# Altium schematic sheet PC.SchDoc(PC)
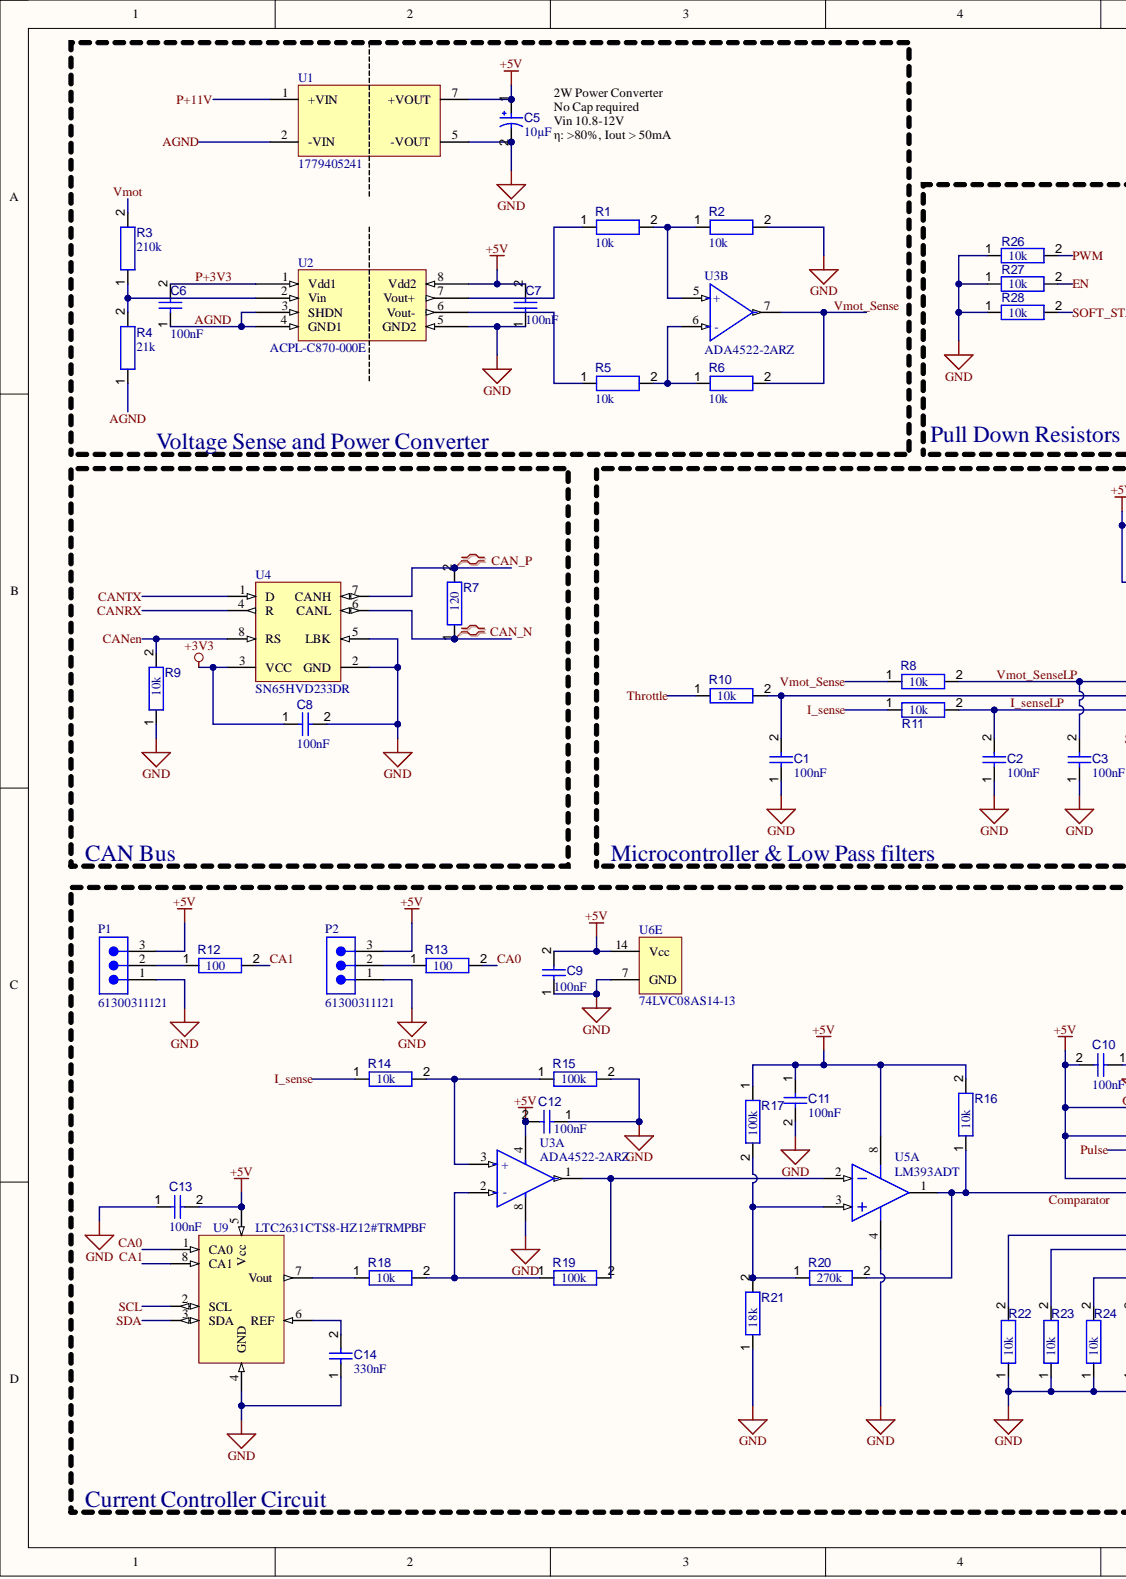
[diagram p0: PC.SchDoc, left]
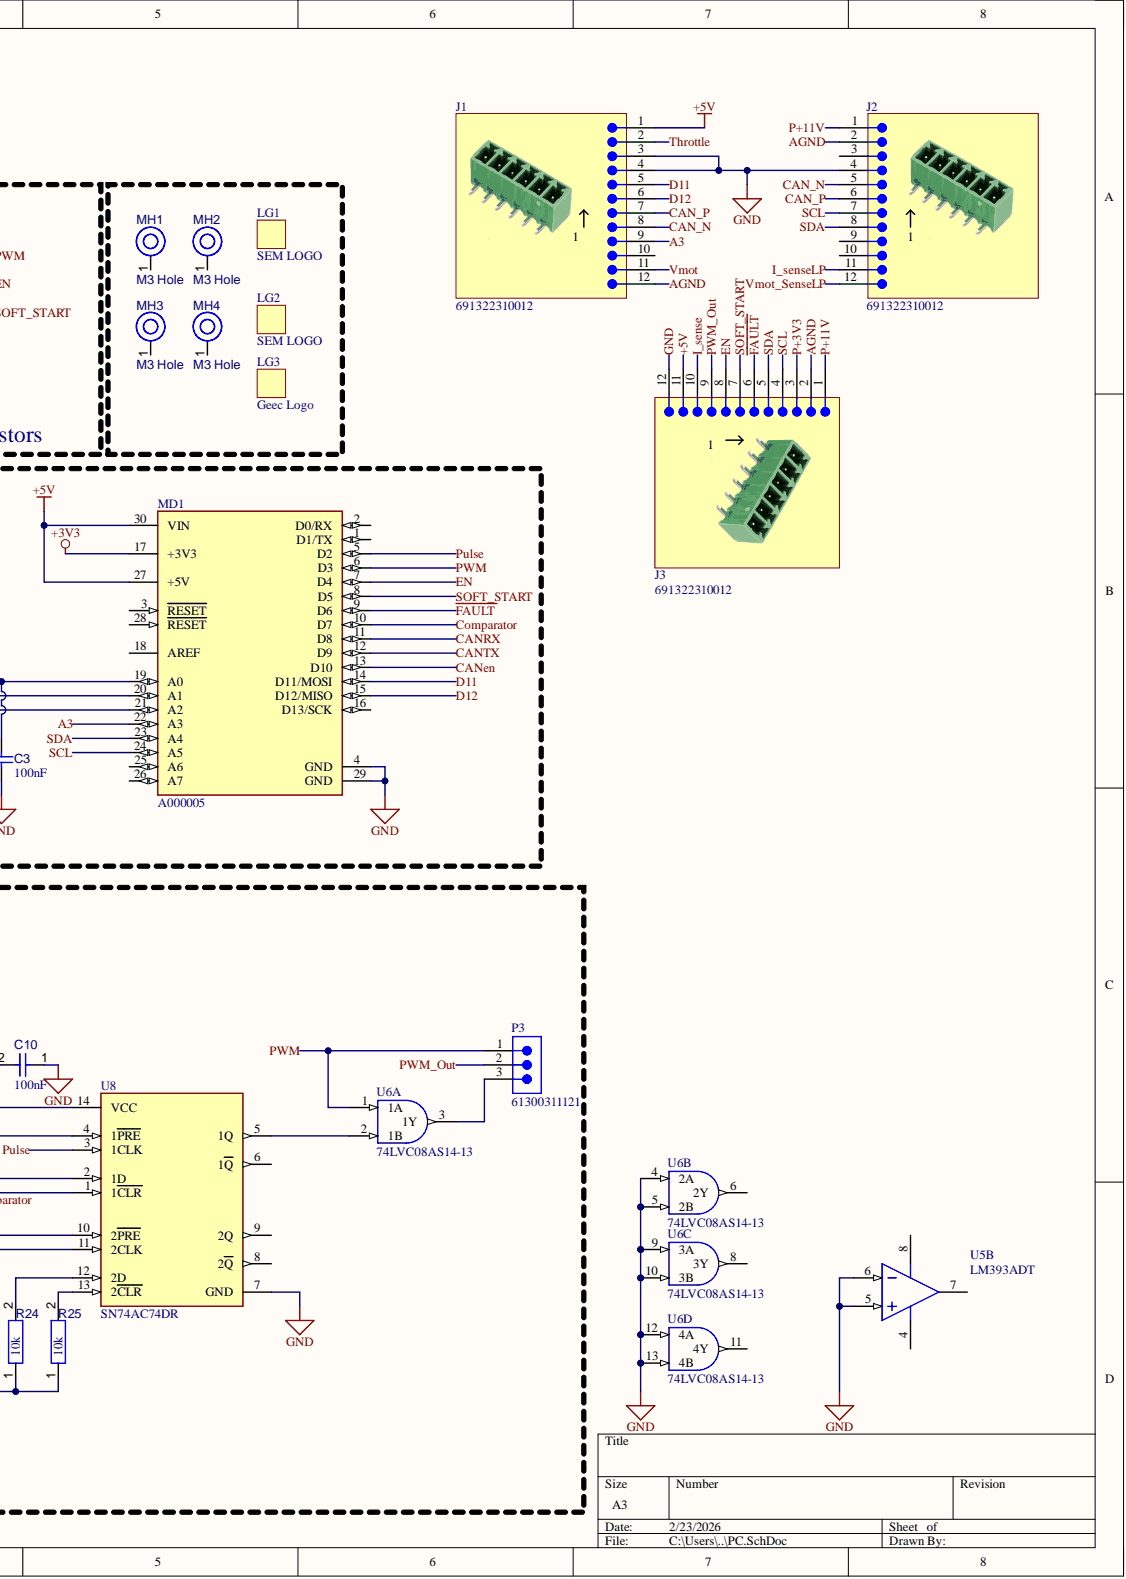
[diagram p1: PC.SchDoc, right]

## Parts
C1  value 100nF  [Cap-THT-Ceramic(7.2X2.5)]  (hidden comment: 100n-THT)
C2  value 100nF  [Cap-THT-Ceramic(7.2X2.5)]  (hidden comment: 100n-THT)
C3  value 100nF  [Cap-THT-Ceramic(7.2X2.5)]  (hidden comment: 100n-THT)
C5  value 10µF  [CAPPR55-200-1100X500X1200]  (hidden comment: ECA1HM100)
C6  value 100nF  [Cap-THT-Ceramic(7.2X2.5)]  (hidden comment: 100n-THT)
C7  value 100nF  [Cap-THT-Ceramic(7.2X2.5)]  (hidden comment: 100n-THT)
C8  value 100nF  [Cap-THT-Ceramic(7.2X2.5)]  (hidden comment: 100n-THT)
C9  value 100nF  [Cap-THT-Ceramic(7.2X2.5)]  (hidden comment: 100n-THT)
C10  value 100nF  [Cap-THT-Ceramic(7.2X2.5)]  (hidden comment: 100n-THT)
C11  value 100nF  [Cap-THT-Ceramic(7.2X2.5)]  (hidden comment: 100n-THT)
C12  value 100nF  [Cap-THT-Ceramic(7.2X2.5)]  (hidden comment: 100n-THT)
C13  value 100nF  [Cap-THT-Ceramic(7.2X2.5)]  (hidden comment: 100n-THT)
C14  value 330nF  [Cap-THT-Ceramic(7.2X2.5)]  (hidden comment: 330nF-THT-Film)
J1  691322310012  [691322310012]
J2  691322310012  [691322310012]
J3  691322310012  [691322310012]
LG1  SEM LOGO  [SEM LOGO-Footprint-1]
LG2  SEM LOGO  [SEM LOGO-Footprint-1]
LG3  Geec Logo  [Geec Logo-Footprint-1]
MD1  A000005  [FP-A000005-MFG]
MH1  M3 Hole  [M3 Hole-Footprint-1]
MH2  M3 Hole  [M3 Hole-Footprint-1]
MH3  M3 Hole  [M3 Hole-Footprint-1]
MH4  M3 Hole  [M3 Hole-Footprint-1]
P1  61300311121  [61300311121]
P2  61300311121  [61300311121]
P3  61300311121  [61300311121]
R1  value 10k  [RESA60-630X240]  (hidden comment: 10k-ThroughHole-250mW)
R2  value 10k  [RESA60-630X240]  (hidden comment: 10k-ThroughHole-250mW)
R3  value 210k  [RESA60-630X240]  (hidden comment: 210k-Throughhole-250mW)
R4  value 21k  [RESA60-630X240]  (hidden comment: 21k-Throughhole-250mW)
R5  value 10k  [RESA60-630X240]  (hidden comment: 10k-ThroughHole-250mW)
R6  value 10k  [RESA60-630X240]  (hidden comment: 10k-ThroughHole-250mW)
R7  value 120  [RESA60-630X240]  (hidden comment: 120Ohm-Throughhole-250mW)
R8  value 10k  [RESA60-630X240]  (hidden comment: 10k-ThroughHole-250mW)
R9  value 10k  [RESA60-630X240]  (hidden comment: 10k-ThroughHole-250mW)
R10  value 10k  [RESA60-630X240]  (hidden comment: 10k-ThroughHole-250mW)
R11  value 10k  [RESA60-630X240]  (hidden comment: 10k-ThroughHole-250mW)
R12  value 100  [RESA60-630X240]  (hidden comment: 100Ohm-ThroughHole-250mW)
R13  value 100  [RESA60-630X240]  (hidden comment: 100Ohm-ThroughHole-250mW)
R14  value 10k  [RESA60-630X240]  (hidden comment: 10k-ThroughHole-250mW)
R15  value 100k  [RESA60-630X240]  (hidden comment: 100k-ThroughHole-250mW)
R16  value 10k  [RESA60-630X240]  (hidden comment: 10k-ThroughHole-250mW)
R17  value 100k  [RESA60-630X240]  (hidden comment: 100k-ThroughHole-250mW)
R18  value 10k  [RESA60-630X240]  (hidden comment: 10k-ThroughHole-250mW)
R19  value 100k  [RESA60-630X240]  (hidden comment: 100k-ThroughHole-250mW)
R20  value 270k  [RESA60-630X240]  (hidden comment: 270k-ThroughHole-250mW)
R21  value 18k  [RESA60-630X240]  (hidden comment: 18kOhm-ThroughHole-250mW)
R22  value 10k  [RESA60-630X240]  (hidden comment: 10k-ThroughHole-250mW)
R23  value 10k  [RESA60-630X240]  (hidden comment: 10k-ThroughHole-250mW)
R24  value 10k  [RESA60-630X240]  (hidden comment: 10k-ThroughHole-250mW)
R25  value 10k  [RESA60-630X240]  (hidden comment: 10k-ThroughHole-250mW)
R26  value 10k  [RESA60-630X240]  (hidden comment: 10k-ThroughHole-250mW)
R27  value 10k  [RESA60-630X240]  (hidden comment: 10k-ThroughHole-250mW)
R28  value 10k  [RESA60-630X240]  (hidden comment: 10k-ThroughHole-250mW)
U1  1779405241  [SIP-7_1779405241]
U2  ACPL-C870-000E  [SOIC127P1150X173-8M]
U3  ADA4522-2ARZ  [FP-R-8-IPC_C]  (parts A,B)
U4  SN65HVD233DR  [D0008A_L]
U5  LM393ADT  [ONSC-SOIC-8-751-07_AJ_V]  (parts A,B)
U6  74LVC08AS14-13  [SOIC127P600X173-14N]  (parts A,B,C,D,E)
U8  SN74AC74DR  [FP-D0014A-MFG]
U9  LTC2631CTS8-HZ12#TRMPBF  [TSOP65P280X100-8N]

## Nets
+3V3: C8.1 MD1.17(+3V3) U4.3(VCC)
+5V: C5.1 C7.2 C9.2 C10.2 C11.1 C12.2 C13.2 J1.1 J3.11 MD1.27(+5V) MD1.30(VIN) P1.3 P2.3 R16.2 R17.1 U1.7(+VOUT) U2.8(Vdd2) U3.4 U5.8 U6.14(Vcc) U8.2(1D) U8.4(1PRE) U8.14(VCC) U9.5(Vcc)
~{FAULT}: J3.6 MD1.9(D6)
A3: J1.9 MD1.22(A3)
AGND: C6.1 J1.12 J2.2 J3.2 R4.1 U1.2(-VIN) U2.3(SHDN) U2.4(GND1)
CA0: R13.2 U9.1(CA0)
CA1: R12.2 U9.8(CA1)
CAN_N: J1.8 J2.5 R7.1 U4.6(CANL)
CAN_P: J1.7 J2.6 R7.2 U4.7(CANH)
CANen: MD1.13(D10) R9.2 U4.8(RS)
CANRX: MD1.11(D8) U4.4(R)
CANTX: MD1.12(D9) U4.1(D)
Comparator: MD1.10(D7) R16.1 R20.2 U5.1 U8.1(1CLR)
D11: J1.5 MD1.14(D11/MOSI)
D12: J1.6 MD1.15(D12/MISO)
EN: J3.8 MD1.7(D4) R27.2
GND: C1.1 C2.1 C3.1 C5.2 C7.1 C8.2 C9.1 C10.1 C11.2 C12.1 C13.1 C14.1 J1.3 J1.4 J2.4 J3.12 MD1.4(GND) MD1.29(GND) P1.1 P2.1 R2.2 R9.1 R15.2 R21.1 R22.1 R23.1 R24.1 R25.1 R26.1 R27.1 R28.1 U1.5(-VOUT) U2.5(GND2) U3.8 U4.2(GND) U4.5(LBK) U5.4 U5.5 U5.6 U6.4(2A) U6.5(2B) U6.7(GND) U6.9(3A) U6.10(3B) U6.12(4A) U6.13(4B) U8.7(GND) U9.4(GND)
I_sense: J3.10 R11.1 R14.1
I_senseLP: C2.2 J2.11 MD1.21(A2) R11.2
P+3V3: C6.2 J3.3 U2.1(Vdd1)
P+11V: J2.1 J3.1 U1.1(+VIN)
Pulse: MD1.5(D2) U8.3(1CLK)
PWM: MD1.6(D3) P3.1 R26.2 U6.1(1A)
PWM_Out: J3.9 P3.2
SCL: J2.7 J3.4 MD1.24(A5) U9.2(SCL)
SDA: J2.8 J3.5 MD1.23(A4) U9.3(SDA)
SOFT_START: J3.7 MD1.8(D5) R28.2
Throttle: J1.2 R10.1
Vmot: J1.11 R3.2
Vmot_Sense: R6.2 R8.1 U3.7
Vmot_SenseLP: C3.2 J2.12 MD1.19(A0) R8.2
NetC1_2: C1.2 MD1.20(A1) R10.2
NetC14_2: C14.2 U9.6(REF)
NetP1_2: P1.2 R12.1
NetP2_2: P2.2 R13.1
NetP3_3: P3.3 U6.3(1Y)
NetR1_1: R1.1 U2.7(Vout+)
NetR1_2: R1.2 R2.1 U3.5(+)
NetR3_1: R3.1 R4.2 U2.2(Vin)
NetR5_1: R5.1 U2.6(Vout-)
NetR5_2: R5.2 R6.1 U3.6(-)
NetR14_2: R14.2 R15.1 U3.3(+)
NetR17_2: R17.2 R20.1 R21.2 U5.3
NetR18_1: R18.1 U9.7(Vout)
NetR18_2: R18.2 R19.1 U3.2(-)
NetR19_2: R19.2 U3.1 U5.2
NetR22_2: R22.2 U8.10(2PRE)
NetR23_2: R23.2 U8.11(2CLK)
NetR24_2: R24.2 U8.12(2D)
NetR25_2: R25.2 U8.13(2CLR)
NetU6_2: U6.2(1B) U8.5(1Q)

Not connected: J1 10; J2 3 9 10; MD1 1(D1/TX) 2(D0/RX) 3(RESET) 16(D13/SCK) 18(AREF) 25(A6) 26(A7) 28(RESET); MH1 1; MH2 1; MH3 1; MH4 1; U5 7; U6 6(2Y) 8(3Y) 11(4Y); U8 6(1Q) 8(2Q) 9(2Q)

## Library data of the parts
C1-C3 C6-C13 = CMP-001-00091-1: 100nF, Throughhole Film Capacitor, 5mm spacing; Tolerance: 10%; Capacitor Type: Film; Case/Package: THT; Component Kind: Standard; Pins: 2; Library Name: University of Galway
C5 = CMP-001-00025-7: Aluminum Electrolytic Capacitor, 10 uF, +/- 20%, 50 V, -40 to 85 degC, 2-Pin THD, RoHS, Bulk; Manufacturer: Panasonic; Ripple Current: 65mA; Voltage Rating: 50V; Capacitor Type: Electrolytic; Case/Package: Radial; Component Kind: Standard; Datasheet URL: https://industrial.panasonic.com/cdbs/www-data/pdf/RDF0000/ABA0000C1218.pdf; Datasheet Version: Rev. 05, 10/2016; Diameter: 5mm; Lead Pitch: 2mm; Manufacturer URL: http://www.panasonic.com/; Max Operating Temperature: 85°C; Min Operating Temperature: -40°C; Mounting Technology: TH; Package Description: 2-Pin Radial Lead, Dia 5 mm, Height 12 mm; Package Quantity: 200; Package Reference: CAPPR55-200-1100X500X1200; Packaging: Bulk; Pins: 2; Ripple Current (AC): 65mA; RoHS Compliant: True; Tolerance: 20%; Library Name: University of Galway
C14 = CMP-001-00092-2: 330nF, Throughhole Film Capacitor, 5mm spacing; Capacitor Type: THT; Tolerance: 10%; Component Kind: Standard; Library Name: University of Galway
J1-J3 = CMP-002-00073-2: Terminal Block, R/A Header, 12Pos, Th Rohs Compliant: Yes; Gender: Male; System: Connector; Component Kind: Standard; Library Name: University of Galway
LG1 LG2 = CMP-00028-00008-2: SEM Logo Required for Power Electronics; Component Kind: Standard; Library Name: University of Galway
LG3 = CMP-00028-00009-2: Component Kind: Standard; Library Name: University of Galway
MD1 = CMP-020-00023-1: ATmega328 Microcontroller Development Board 16MHz CPU 2KB RAM 1KB/32KB EEPROM/Flash; Interface: I2C, SPI, USB; Max Supply Voltage: 12V; Min Supply Voltage: 7V; Component Kind: Standard; Frequency: 16MHz; Library Name: University of Galway
MH1-MH4 = CMP-00028-00007-2: Hole for M3 bolt; Component Kind: Standard; Library Name: University of Galway
P1-P3 = CMP-002-00212-1: WR-PHD Pin Header, THT, Vertical, pitch 2.54mm, 1 Row, 3P; Manufacturer: Wurth Elektronik; Contact Resistance: 20mOhm; Rated Current: 3A; Withstanding Voltage: 500V; Working Voltage: 250V; Category: Board-to-Board Connectors; Component Kind: Standard; Component Type: Standard; ComponentLink1Description: Website Link; ComponentLink1URL: https://www.we-online.com/redexpert/article/61300311121?ad; ComponentLink2Description: Datasheet Link; ComponentLink2URL: https://www.we-online.com/redexpert/spec/61300311121?ad; Design Item ID: 61300311121; Gender: Pin Header; Manufacturer Part Number: 61300311121; Match Code: WR-PHD; Mount: Through Hole; Number of Rows: 1; Operating Temperature Max: 105°C; Operating Temperature Min: -40°C; Orientation: Vertical; Packaging: Bag; Pin Count: 3; Pins: 3; Pitch: 2.54mm; Source: WR-PHD.SchLib; Library Name: University of Galway
R1 R2 R5 R6 R8-R11 R14 R16 R18 R22-R28 = CMP-009-00196-1: 10kOhm Resistor, Through Hole, 1/4W; Power: 250mW; Tolerance: 5%; Voltage Rating: 50V; Case/Package: THT; Component Kind: Standard; Pins: 2; Library Name: University of Galway
R3 = CMP-009-00200-2: 210kOhm Resistor, Throughhole, 1/4W; Power: 250mW; Tolerance: 5%; Voltage Rating: 229V; Case/Package: Throughhole; Component Kind: Standard; Library Name: University of Galway
R4 = CMP-009-00201-1: 21kOhm Resistor, Throughhole, 1/4W; Power: 250mW; Tolerance: 5%; Voltage Rating: 72V; Case/Package: Throughhole; Component Kind: Standard; Mounting Technology: Throughhole; Library Name: University of Galway
R7 = CMP-009-00199-2: 120Ohm Resistor, Through Hole, 1/4W; Power: 250mW; Tolerance: 5%; Voltage Rating: 5.5V; Case/Package: Throughole; Component Kind: Standard; Mounting Technology: Throughhole; Library Name: University of Galway
R12 R13 = CMP-009-00195-1: 100Ohm Resistor Through Hole 1/4W; Power: 250mW; Tolerance: 5%; Voltage Rating: 5V; Case/Package: THT; Component Kind: Standard; Library Name: University of Galway
R15 R17 R19 = CMP-009-00192-1: 100kOhm Resistor, Through hole, 250mW; Tolerance: 5%; Voltage Rating: 158V; Case/Package: ThroughHole; Component Kind: Standard; Power: 250mW; Library Name: University of Galway
R20 = CMP-009-00198-1: 270kOhm Resistor, Through Hole, 1/4W; Power: 250mW; Tolerance: 5%; Voltage Rating: 244V; Case/Package: THT; Component Kind: Standard; Mounting Technology: THT; Pins: 2; Library Name: University of Galway
R21 = CMP-009-00197-1: 18kOhm Resistor, Through Hole, 1/4W; Power: 250mW; Tolerance: 5%; Voltage Rating: 67V; Case/Package: THT; Component Kind: Standard; Mounting Technology: THT; Pins: 2; Library Name: University of Galway
U1 = CMP-013-000028-1: WPME-FISM Fixed Isolated Module, SIP-7, 10.8 - 13.2 Vin, 5 Vout; Manufacturer: Wurth Elektronik; Isolation Voltage: 3000 V; Output Power: 2 W; Output Voltage: 5 V; Short Circuit Protection: continuous; Type of Insulation: Functional; Case/Size Code: SIP-7; Category: Isolated Converter; Component Kind: Standard; Component Type: Standard; ComponentLink1Description: Website Link; ComponentLink1URL: https://www.we-online.com/redexpert/article/1779405241?ad; ComponentLink2Description: Datasheet Link; ComponentLink2URL: https://www.we-online.com/redexpert/spec/1779405241?ad; Design Item ID: 1779405241; Height: 10.16 mm; Input Voltage: 10.8 - 13.2 V; Length: 19.65 mm; Manufacturer Part Number: 1779405241; Match Code: WPME-FISM; Max Operating Temperature: 105 °C; Min Operating Temperature: -40 °C; Mount: Through Hole; Packaging: Tube; Pin Count: 4; Source: WPME-FISM.SchLib; Width: 7.05 mm; Library Name: University of Galway
U2 = CMP-00004-3: IC OPAMP ISOLATION 1 CIRC 8SO Isolation Amplifier 1 Circuit Differential 8-SO Stretched; -3db Bandwidth: 100kHz; Forward Current: 230mA; Input Bias Current: 1.5nA; Input Current: 10.5mA; Input Offset Voltage (Vos): 300µV; Isolation Voltage: 5kV; Max Supply Voltage: 5.5V; Min Supply Voltage: 3V; Number of Amplifiers: 1; Operating Supply Voltage: 5V; Output Current per Channel: 30mA; Output Type: ANALOG, Digital; Propagation Delay: 6.5µs; Bandwidth: 100kHz; Case/Package: SO; China RoHS: Compliant; Component Kind: Standard; Contact Plating: Tin; Height: 3.18mm; Height - Seated (Max): 3.607mm; Length: 6.807mm; Lifecycle Status: Production; Max Operating Temperature: 105°C; Min Operating Temperature: -40°C; Mounting Technology: Surface Mount; Number of Channels: 1; Number of Circuits: 1; Number of Terminals: 8; Operating Supply Current: 10.5mA; Pins: 8; Radiation Hardening: No; REACH SVHC: Yes; RoHS: Compliant; Terminal Pitch: 1.27mm; Turn-On Delay Time: 6.5µs; Width: 5.85mm; Library Name: University of Galway
U3 = CMP-014-00005-1: IC OPAMP ZERO-DRIFT 2 CIRC 8SOIC Zero-Drift Amplifier 2 Circuit Rail-to-Rail 8-SOIC; Max Supply Voltage: 55V; Min Supply Voltage: 4.5V; Case/Package: SOIC; Component Kind: Standard; Max Operating Temperature: 125°C; Min Operating Temperature: -40°C; Mounting Technology: Surface Mount; Pins: 8; Library Name: University of Galway
U4 = CMP-015-00010-1: 3.3 V CAN Transceiver with Standby Mode, Loopback 8-SOIC -40 to 125; Max Supply Voltage: 3.6V; Min Supply Voltage: 3V; Case/Package: SOIC; Component Kind: Standard; Max Operating Temperature: 125°C; Min Operating Temperature: -40°C; Pins: 8; Library Name: University of Galway
U5 = CMP-014-00006-1: LM393 Series Dual 36 V 100 nA SMT Low Power Voltage Comparator - SOIC-8; Max Supply Current: 2.5mA; Max Supply Voltage: 36V; Min Supply Voltage: 2V; Number of Channels: 2; Output Type: TTL; Case/Package: SO; Component Kind: Standard; Max Operating Temperature: 70°C; Min Operating Temperature: 0°C; Mounting Technology: Surface Mount; Pins: 8; Library Name: University of Galway
U6 = CMP-016-00011-1: 74LVC Series 5.5 V Surface Mount Quadruple 2-Input AND Gate - SOIC-14; Max Supply Voltage: 5.5V; Min Supply Voltage: 1.65V; Case/Package: SO; Component Kind: Standard; Max Operating Temperature: 125°C; Min Operating Temperature: -40°C; Mounting Technology: Surface Mount; Pins: 14; Library Name: University of Galway
U8 = CMP-016-00012-1: Dual Positive-Edge-Triggered D-Type Flip-Flops With Clear and Preset 14-SOIC -40 to 85; Frequency: 160MHz; Max Supply Voltage: 6V; Min Supply Voltage: 2V; Nominal Supply Current: 2µA; Case/Package: SOIC; Component Kind: Standard; Max Operating Temperature: 85°C; Min Operating Temperature: -40°C; Mounting Technology: Surface Mount; Pins: 14; Library Name: University of Galway
U9 = CMP-00024-00009-3: IC DAC 12BIT V-OUT TSOT23-8 12 Bit Digital to Analog Converter 1 TSOT-23-8; Interface: 2-Wire, I2C; Max Supply Voltage: 5.5V; Min Supply Voltage: 4.5V; Number of Bits: 12; Number of Channels: 1; Case/Package: TSOT; Component Kind: Standard; Max Operating Temperature: 70°C; Min Operating Temperature: 0°C; Mounting Technology: Surface Mount; Pins: 8; Library Name: University of Galway
